# Altium schematic sheet BLDC_Gimbal_Top.SchDoc
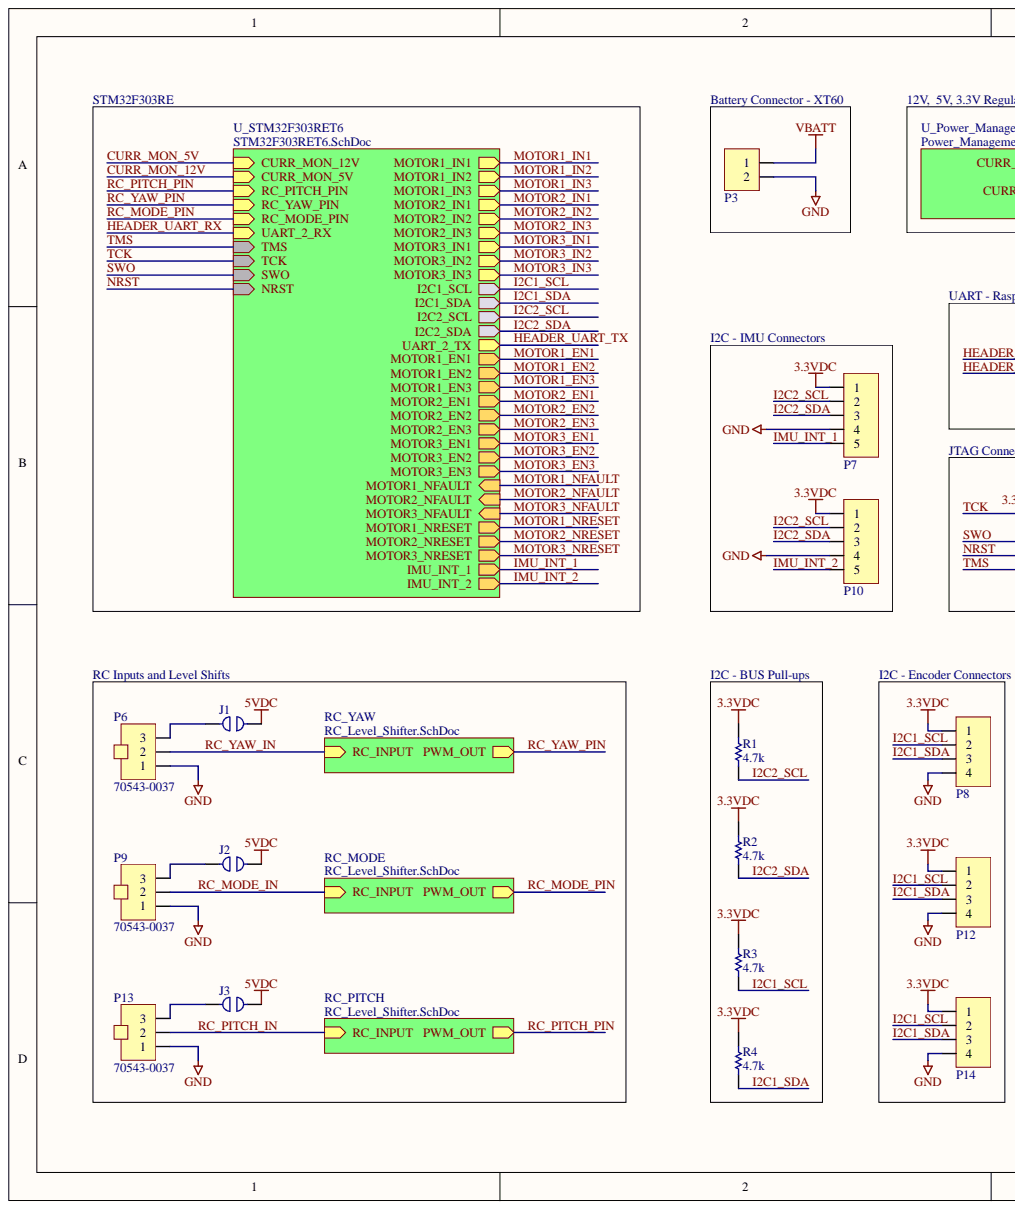
[diagram p0: BLDC_Gimbal_Top.SchDoc, left]
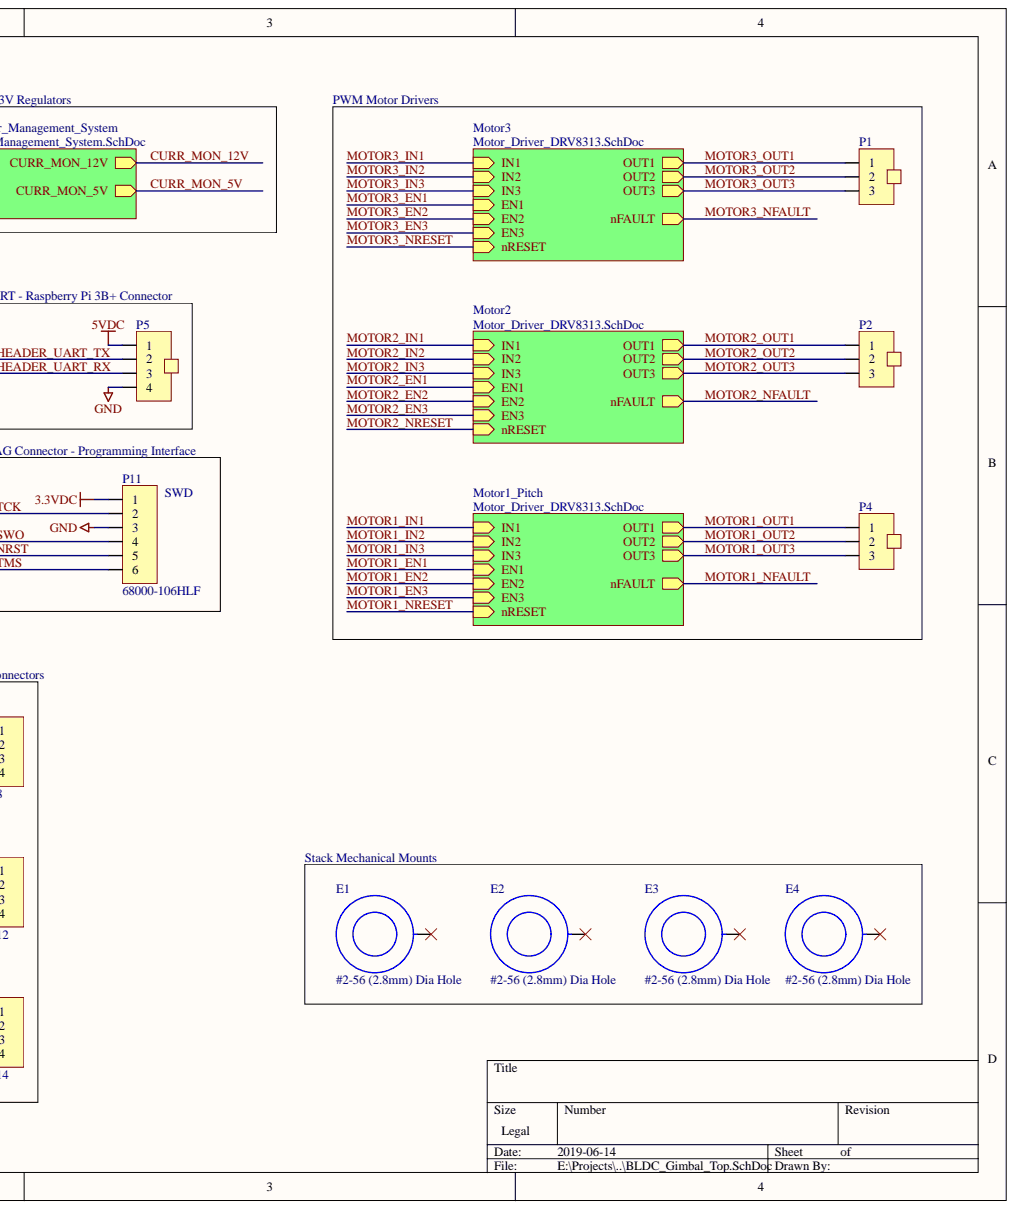
[diagram p1: BLDC_Gimbal_Top.SchDoc, right]

## Parts
E1  #2-56 (2.8mm) Dia Hole  [2-56, Mounting Hole]  (no BOM)
E2  #2-56 (2.8mm) Dia Hole  [2-56, Mounting Hole]  (no BOM)
E3  #2-56 (2.8mm) Dia Hole  [2-56, Mounting Hole]  (no BOM)
E4  #2-56 (2.8mm) Dia Hole  [2-56, Mounting Hole]  (no BOM)
J1  3M9580-ND  [Jumper-2Pin, THT]  (value not drawn)
J2  3M9580-ND  [Jumper-2Pin, THT]  (value not drawn)
J3  3M9580-ND  [Jumper-2Pin, THT]  (value not drawn)
P1  70543-0037  [Header-3Pin, THT, Shrouded]
P2  70543-0037  [Header-3Pin, THT, Shrouded]
P3  2x1-Pin, Pitch: 3.00mm, Battery Connector  [XT60]  (value not drawn)
P4  70543-0037  [Header-3Pin, THT, Shrouded]
P5  43045-0412  [Header-4Pin, 2x2, THT, Locking, Tin]  (value not drawn)
P6  70543-0037  [Header-3Pin, THT, Shrouded]
P7  B5B-ZR-SM4-TF(LF)(SN)  [1x5-PIN ZH 1.5mm Pitch]  (value not drawn)
P8  B4B-ZR-SM4-TF(LF)(SN)  [1x4-PIN ZH 1.5mm Pitch]  (value not drawn)
P9  70543-0037  [Header-3Pin, THT, Shrouded]
P10  B5B-ZR-SM4-TF(LF)(SN)  [1x5-PIN ZH 1.5mm Pitch]  (value not drawn)
P11  68000-106HLF  [Header-6Pin, THT]
P12  B4B-ZR-SM4-TF(LF)(SN)  [1x4-PIN ZH 1.5mm Pitch]  (value not drawn)
P13  70543-0037  [Header-3Pin, THT, Shrouded]
P14  B4B-ZR-SM4-TF(LF)(SN)  [1x4-PIN ZH 1.5mm Pitch]  (value not drawn)
R1  4.7k  [RESC2012N(0805")]
R2  4.7k  [RESC2012N(0805")]
R3  4.7k  [RESC2012N(0805")]
R4  4.7k  [RESC2012N(0805")]

## Nets
3.3VDC: P7.1 P8.1 P10.1 P11.1 P12.1 P14.1 R1.2 R2.2 R3.2 R4.2
5VDC: J1.2 J2.2 J3.2 P5.1
GND: P3.2 P5.4 P6.1 P7.4 P8.4 P9.1 P10.4 P11.3 P12.4 P13.1 P14.4
VBATT: P3.1
HEADER_UART_RX: P5.3
HEADER_UART_TX: P5.2
I2C1_SCL: P8.2 P12.2 P14.2 R3.1
I2C1_SDA: P8.3 P12.3 P14.3 R4.1
I2C2_SCL: P7.2 P10.2 R1.1
I2C2_SDA: P7.3 P10.3 R2.1
IMU_INT_1: P7.5
IMU_INT_2: P10.5
MOTOR1_OUT1: P4.1
MOTOR1_OUT2: P4.2
MOTOR1_OUT3: P4.3
MOTOR2_OUT1: P2.1
MOTOR2_OUT2: P2.2
MOTOR2_OUT3: P2.3
MOTOR3_OUT1: P1.1
MOTOR3_OUT2: P1.2
MOTOR3_OUT3: P1.3
NRST: P11.5
RC_MODE_IN: P9.2
RC_PITCH_IN: P13.2
RC_YAW_IN: P6.2
SWO: P11.4
TCK: P11.2
TMS: P11.6
NetE1_0: E1.0
NetE2_0: E2.0
NetE3_0: E3.0
NetE4_0: E4.0
NetJ1_1: J1.1 P6.3
NetJ2_1: J2.1 P9.3
NetJ3_1: J3.1 P13.3
CURR_MON_5V:
CURR_MON_12V:
MOTOR1_EN1:
MOTOR1_EN2:
MOTOR1_EN3:
MOTOR1_IN1:
MOTOR1_IN2:
MOTOR1_IN3:
MOTOR1_NFAULT:
MOTOR1_NRESET:
MOTOR2_EN1:
MOTOR2_EN2:
MOTOR2_EN3:
MOTOR2_IN1:
MOTOR2_IN2:
MOTOR2_IN3:
MOTOR2_NFAULT:
MOTOR2_NRESET:
MOTOR3_EN1:
MOTOR3_EN2:
MOTOR3_EN3:
MOTOR3_IN1:
MOTOR3_IN2:
MOTOR3_IN3:
MOTOR3_NFAULT:
MOTOR3_NRESET:
RC_MODE_PIN:
RC_PITCH_PIN:
RC_YAW_PIN:

## Library data of the parts
E1-E4 = #2-56, w-Pin, Mounting Hole: #2-56, with Pin, Mounting Hole; Published: Feb 8, 2014; Library Name: AERO Integrated Library - Miscellaneous.IntLib; Publisher: UVic AERO, KCMR
J1-J3 = Jumper, 0.1" (2.54mm), Gold, THT, 68001-202HLF: Jumper, 0.1" (2.54mm), Gold, THT, 68001-202HLF & 3M9580-ND; Mfg: FCI; Component Kind: Standard; Mfg#: 68001-202HLF; Published: 2014-10-19; Supplier 1: Digi-Key; Supplier 2: Digi-Key; Supplier Part Number 1: 3M9580-ND; Supplier Part Number 2: 609-3469-ND; Verified: No; Library Name: AERO Schematic Library - Miscellaneous.SchLib; Publisher: UVic AERO, Kirk Richardson
P1 P2 P4 P6 P9 P13 = 1x3-Pin, Pitch: 0.1" (2.54mm), Tin, THT, Shrouded, Locking, 70543-0037: Mfg: Molex Inc; ReviewedBy: Matthew Fitz; ReviewedNote: There should be tin pins instead of gold; Component Kind: Standard; Description: 1x3-Pin, Pitch: 0.1" (2.54mm), Tin, THT, Shrouded, Locking, 70543-0037; LatestRevisionDate: 2014-03-18; LatestRevisionNote: Changed # of pins from 4 to 3; Mfg#: 70543-0037; Published: 2014-03-16; ReviewedDate: 2014-03-19; Supplier 1: Digi-Key; Supplier Part Number 1: WM4825-ND; Verified: Yes; Library Name: AERO Schematic Library - Connectors.SchLib; Publisher: UVic AERO, Kirk Richardson
P5 = 2x2-Pin, Pitch: 3.00mm, Tin, THT, 43045-0412: 2x2-Pin, Pitch: 3.00mm, Tin, THT, Shrouded, Locking, 43045-0412; LatestRevisionBy: Kirk Richardson; Mfg: Molex; Component Kind: Standard; LatestRevisionDate: 2014-03-23; LatestRevisionNote: Fixed footprint so it's aligned to pin 1; Mfg#: 43045-0412; Published: 2014-03-13; ReviewedDate: 2014-03-23; Supplier 1: Digi-Key; Supplier Part Number 1: WM1790-ND; Verified: Yes; Library Name: AERO Schematic Library - Connectors.SchLib; Publisher: UVic AERO, Taylor Eby; ReviewedBy: Kirk Richardson
P7 P10 = B5B-ZR-SM4-TF(LF)(SN): CONN HEADER ZH TOP 5POS 1.5MM; Manufacturer: JST; Connector Type: Male Pin; Current Rating: 1A; Gender: Male; Number of Contacts: 5; Pitch: 0.059inch; Color: Natural; Component Kind: Standard; ComponentLink1Description: http://www.jst-mfg.com/product/pdf/eng/eZH.pdf?src-supplier=Digi-Key; ComponentLink1URL: http://www.jst-mfg.com/product/pdf/eng/eZH.pdf?src-supplier=Digi-Key; ComponentLink2Description: http://www.jst-mfg.com/product/pdf/eng/eZR.pdf?src-supplier=Future+Electronics; ComponentLink2URL: http://www.jst-mfg.com/product/pdf/eng/eZR.pdf?src-supplier=Future+Electronics; Contact Material: Tin; Contact Plating: Tin; Fastening Type: Detent; Height: 7.2mm; Housing Color: Natural; Housing Material: Nylon; Lead Free: Lead Free; Length: 10.5mm; Manufacturer Part Number: B5B-ZR-SM4-TF(LF)(SN); Mount: Surface Mount; Number of Positions: 5; Number of Rows: 1; Packaging: Tape and Reel; Pricing 1: 1 = 0.790000, 10 = 0.742000, 100 = 0.568600, 500 = 0.494420 (USD); RoHS: Yes; Stock 1: 52019; Supplier 1: Digi-Key; Supplier Part Number 1: 455-1684-1-ND; Termination: Solder; Width: 3.5mm; Library Name: BLDC_Gimbal_Prototype_Lib.SCHLIB
P8 P12 P14 = B4B-ZR-SM4-TF(LF)(SN): Conn Shrouded Header HDR 4 POS 1.5mm Solder ST SMD T/R; Manufacturer: JST; Connector Type: Male Pin; Contact Resistance: 30mR; Current Rating: 1A; Gender: Male; Max Voltage Rating (AC): 50V; Number of Contacts: 4; Color: Natural; Component Kind: Standard; ComponentLink1Description: https://datasheet.ciiva.com/26924/getdatasheetpartid-640935-26924037.pdf?src-supplier=Verical; ComponentLink1URL: https://datasheet.ciiva.com/26924/getdatasheetpartid-640935-26924037.pdf?src-supplier=Verical; Contact Material: Tin; Contact Plating: Tin; Depth: 3.7mm; Fastening Type: Detent; Height: 7.2mm; Housing Color: Beige; Housing Material: Polyamide; Lead Free: Lead Free; Length: 9mm; Manufacturer Part Number: B4B-ZR-SM4-TF(LF)(SN); Max Current Rating: 1A; Max Operating Temperature: 85°C; Min Operating Temperature: -25°C; Mount: Surface Mount; Number of Positions: 4; Number of Rows: 1; Orientation: Straight; Packaging: Tape and Reel; Pitch: 1.5mm; Pricing 1: 1 = 0.71000, 10 = 0.67000, 100 = 0.51380, 500 = 0.44682 (USD); RoHS: Yes; Stock 1: 27718; Supplier 1: Digi-Key; Supplier Part Number 1: 455-1683-1-ND; Termination: Solder; Width: 3.5mm; Library Name: BLDC_Gimbal_Prototype_Lib.SCHLIB
P11 = Header, 1x6-Pin, Pitch: 0.1" (2.54mm), Gold 30µin, THT, 68000-106HLF: Mfg: FCI; Component Kind: Standard; Description: Header, 1x6-Pin, Pitch: 0.1" (2.54mm), Gold 30µin, THT, 68000-106HLF; Mfg#: 68000-106HLF; Published: 2014-03-16; Supplier 1: Digi-Key; Supplier Part Number 1: 609-3249-ND; Verified: No; Library Name: AERO Integrated Library - Connectors.IntLib; Publisher: UVic AERO, Kirk Richardson
R1-R4 = RC0805FR-074K7L: RES SMD 4.7k ohm, 150 V, 0805 [2012 Metric], 250 mW,  1%; Manufacturer: Yageo; Max Power Dissipation: 125mW; Resistance: 4.7kR; Temperature Coefficient: 100ppm/°C; Voltage Rating: 150V; Case/Package: 0805; Case Code (Imperial): 0805; Case Code (Metric): 2012; Component Kind: Standard; ComponentLink1Description: https://datasheet.ciiva.com/11808/0900766b8106beab-11808155.pdf?src-supplier=RSComponents; ComponentLink1URL: https://datasheet.ciiva.com/11808/0900766b8106beab-11808155.pdf?src-supplier=RSComponents; ComponentLink2Description: https://datasheet.ciiva.com/7941/1686128-7941805.pdf?src-supplier=Element14; ComponentLink2URL: https://datasheet.ciiva.com/7941/1686128-7941805.pdf?src-supplier=Element14; ComponentLink3Description: https://datasheet.ciiva.com/7941/444164-7941806.pdf?src-supplier=Element14; ComponentLink3URL: https://datasheet.ciiva.com/7941/444164-7941806.pdf?src-supplier=Element14; ComponentLink4Description: https://datasheet.ciiva.com/6547/pyu-r-int-thick-7-6547263.pdf?src-supplier=Digi-Key; ComponentLink4URL: https://datasheet.ciiva.com/6547/pyu-r-int-thick-7-6547263.pdf?src-supplier=Digi-Key; ComponentLink5Description: https://datasheet.ciiva.com/7941/444164-7941804.pdf?src-supplier=Element14; ComponentLink5URL: https://datasheet.ciiva.com/7941/444164-7941804.pdf?src-supplier=Element14; ComponentLink6Description: https://datasheet.ciiva.com/46447/444164-46447583.pdf?src-supplier=Element14; ComponentLink6URL: https://datasheet.ciiva.com/46447/444164-46447583.pdf?src-supplier=Element14; Composition: Thick Film; Depth: 1.25mm; Features: Moisture Resistant; Height: 0.024inch; Lead Free: Lead Free; Length: 0.079inch; Manufacturer Part Number: RC0805FR-074K7L; Max Operating Temperature: 155°C; Military Standard: Not; Min Operating Temperature: -55°C; Number of Pins: 2; Number of Terminations: 2; Package Quantity: 5000; Packaging: Tape and Reel; Power Rating: 125mW; Pricing 1: 1 = 0.10000, 10 = 0.04200, 100 = 0.01700, 1000 = 0.00762, 2500 = 0.00662 (USD); REACH SVHC: No SVHC; RoHS: Yes; Stock 1: 5392599; Supplier 1: Digi-Key; Supplier Part Number 1: 311-4.70KCRCT-ND; Termination: SMD/SMT; Tolerance: 1%; Voltage Rating (DC): 150V; Width: 0.049inch; Library Name: BLDC_Gimbal_Prototype_Lib.SCHLIB
P3 = 2x1-Pin, Pitch: 3.00mm, Battery Connector: Component Kind: Standard; Description: 2x1-Pin, Pitch: 3.00mm, Battery Connector; Published: 2016-12-15; Library Name: BLDC_Gimbal_Prototype_Lib.SCHLIB; Publisher: UVic AERO, Luke Mills
